# Altium schematic sheet ASIC-Board.SchDoc(ASIC-Board)
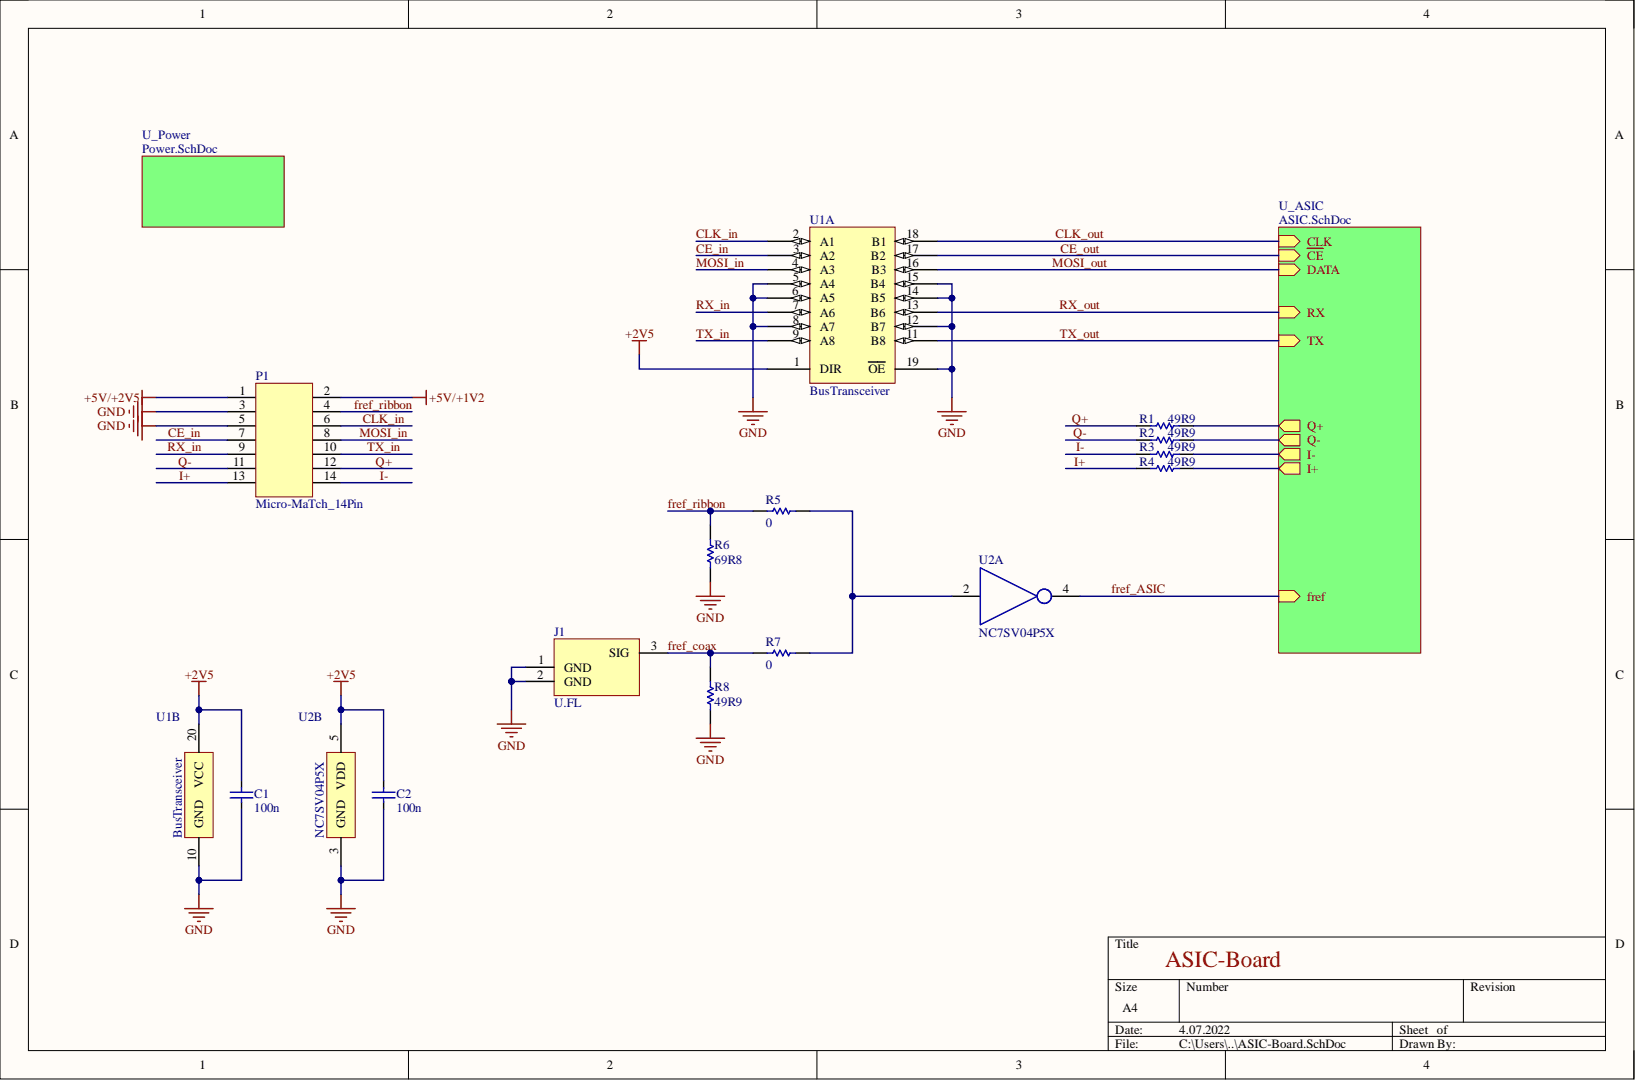
[diagram p0: ASIC-Board.SchDoc]

## Parts
C1  100n  [CAP_0402]
C2  100n  [CAP_0402]
J1  U.FL  [U.FL]
P1  Micro-MaTch_14Pin  [Mikro-MaTch_14Pin_SMD]
R1  49R9  [RES_0402]
R2  49R9  [RES_0402]
R3  49R9  [RES_0402]
R4  49R9  [RES_0402]
R5  0  [RES_0402]
R6  69R8  [RES_0402]
R7  0  [RES_0402]
R8  49R9  [RES_0402]
U1  BusTransceiver  [TSSOP-20]  (parts A,B)
U2  NC7SV04P5X  [SOT23-5_0.65mm]  (parts A,B)

## Nets
+2V5: C1.1 C2.1 U1.1(DIR) U1.20(VCC) U2.5(VDD)
+5V/+1V2: P1.2
+5V/+2V5: P1.1
GND: C1.2 C2.2 J1.1 J1.2 P1.3 P1.5 R6.1 R8.1 U1.5(A4) U1.6(A5) U1.8(A7) U1.10(GND) U1.12(B7) U1.14(B5) U1.15(B4) U1.19(OE) U2.3(GND)
CE_in: P1.7 U1.3(A2)
CE_out: U1.17(B2)
CLK_in: P1.6 U1.2(A1)
CLK_out: U1.18(B1)
fref_ASIC: U2.4
fref_coax: J1.3 R7.2 R8.2
fref_ribbon: P1.4 R5.2 R6.2
I+: P1.13 R4.2
I-: P1.14 R3.2
MOSI_in: P1.8 U1.4(A3)
MOSI_out: U1.16(B3)
Q+: P1.12 R1.2
Q-: P1.11 R2.2
RX_in: P1.9 U1.7(A6)
RX_out: U1.13(B6)
TX_in: P1.10 U1.9(A8)
TX_out: U1.11(B8)
NetR1_1: R1.1
NetR2_1: R2.1
NetR3_1: R3.1
NetR4_1: R4.1
NetR5_1: R5.1 R7.1 U2.2

## Library data of the parts
C1 C2 = Cap: Component Kind: Standard; Value: 100n; Library Name: IIS-Lib_FD.IntLib
R1-R8 = Res: Component Kind: Standard; Library Name: IIS-Lib_FD.IntLib
  R1-R4 R8: Value: 49R9
  R5 R7: Value: 0
  R6: Value: 69R8
U1 = 74LVC245A: Component Kind: Standard; Library Name: IIS-Lib_FD.IntLib
J1 = U.FL: Component Kind: Standard; Library Name: IIS-Lib_FD.IntLib
P1 = Micro-MaTch_14Pin: Component Kind: Standard; Library Name: IIS-Lib_FD.IntLib
U2 = NC7SV04P5X: Component Kind: Standard; Library Name: IIS-Lib_FD.IntLib
